# Altium schematic sheet lm1875 amplifier.SchDoc("lm1875 amplifier")
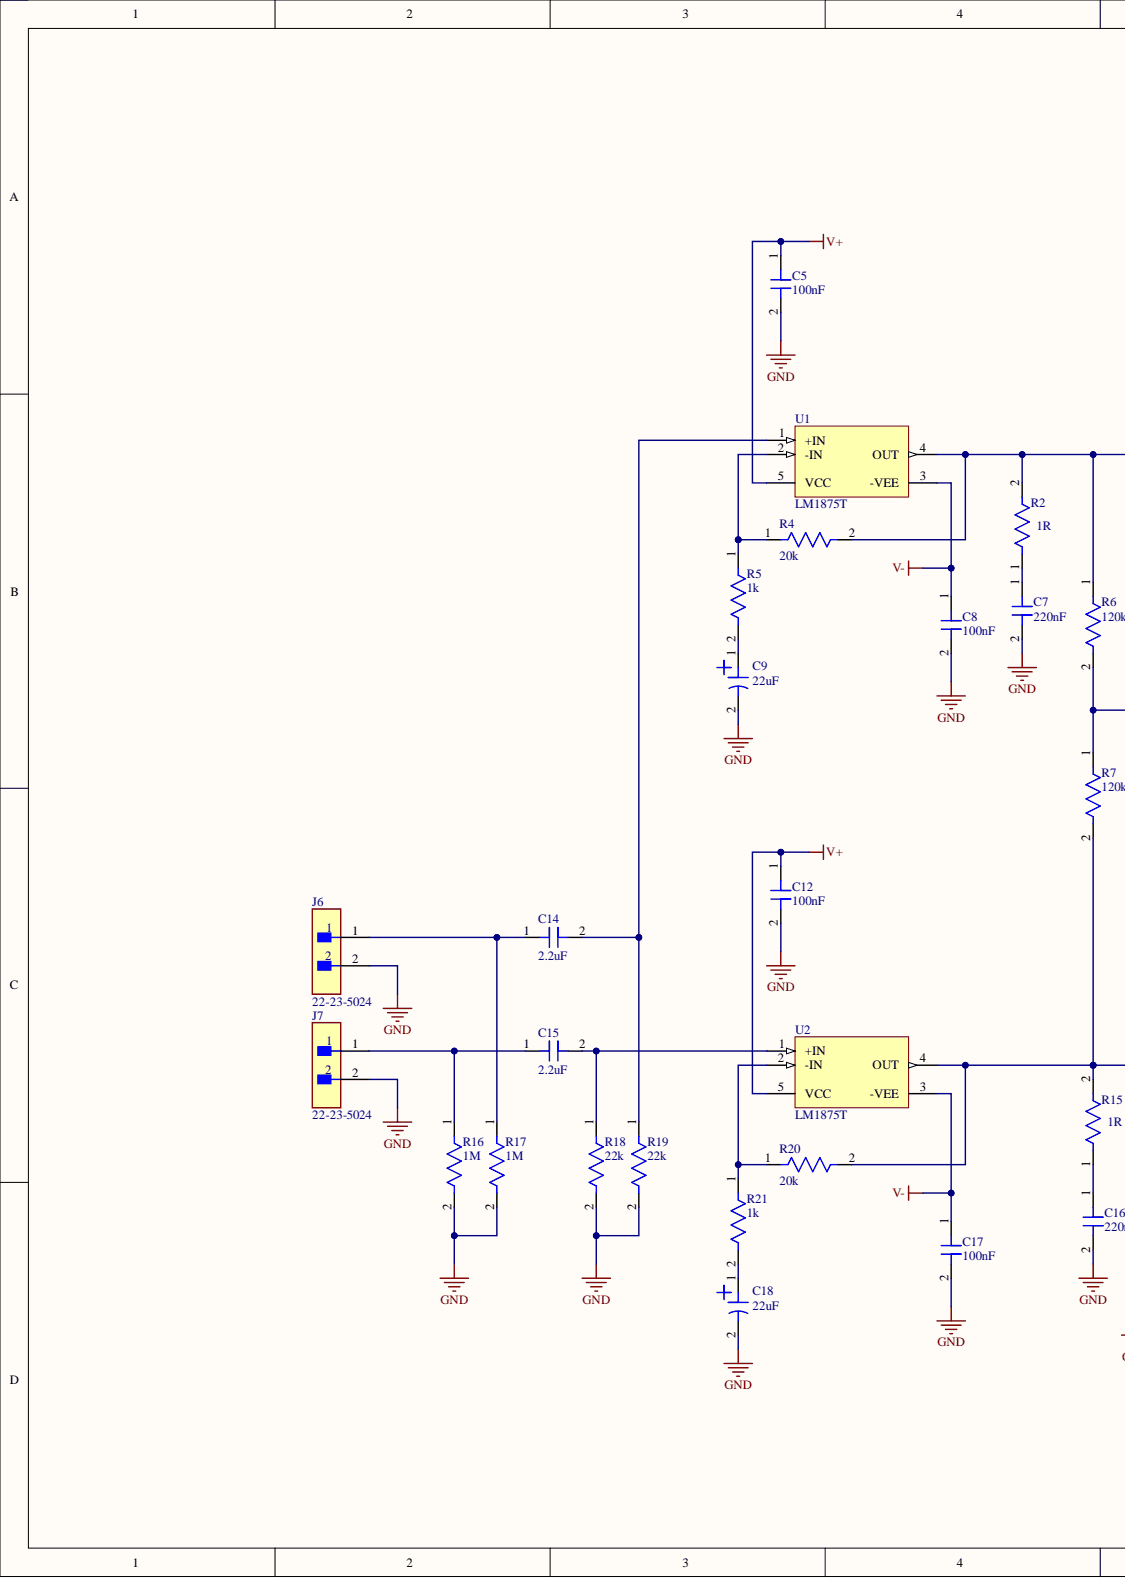
[diagram p0: lm1875 amplifier.SchDoc, left]
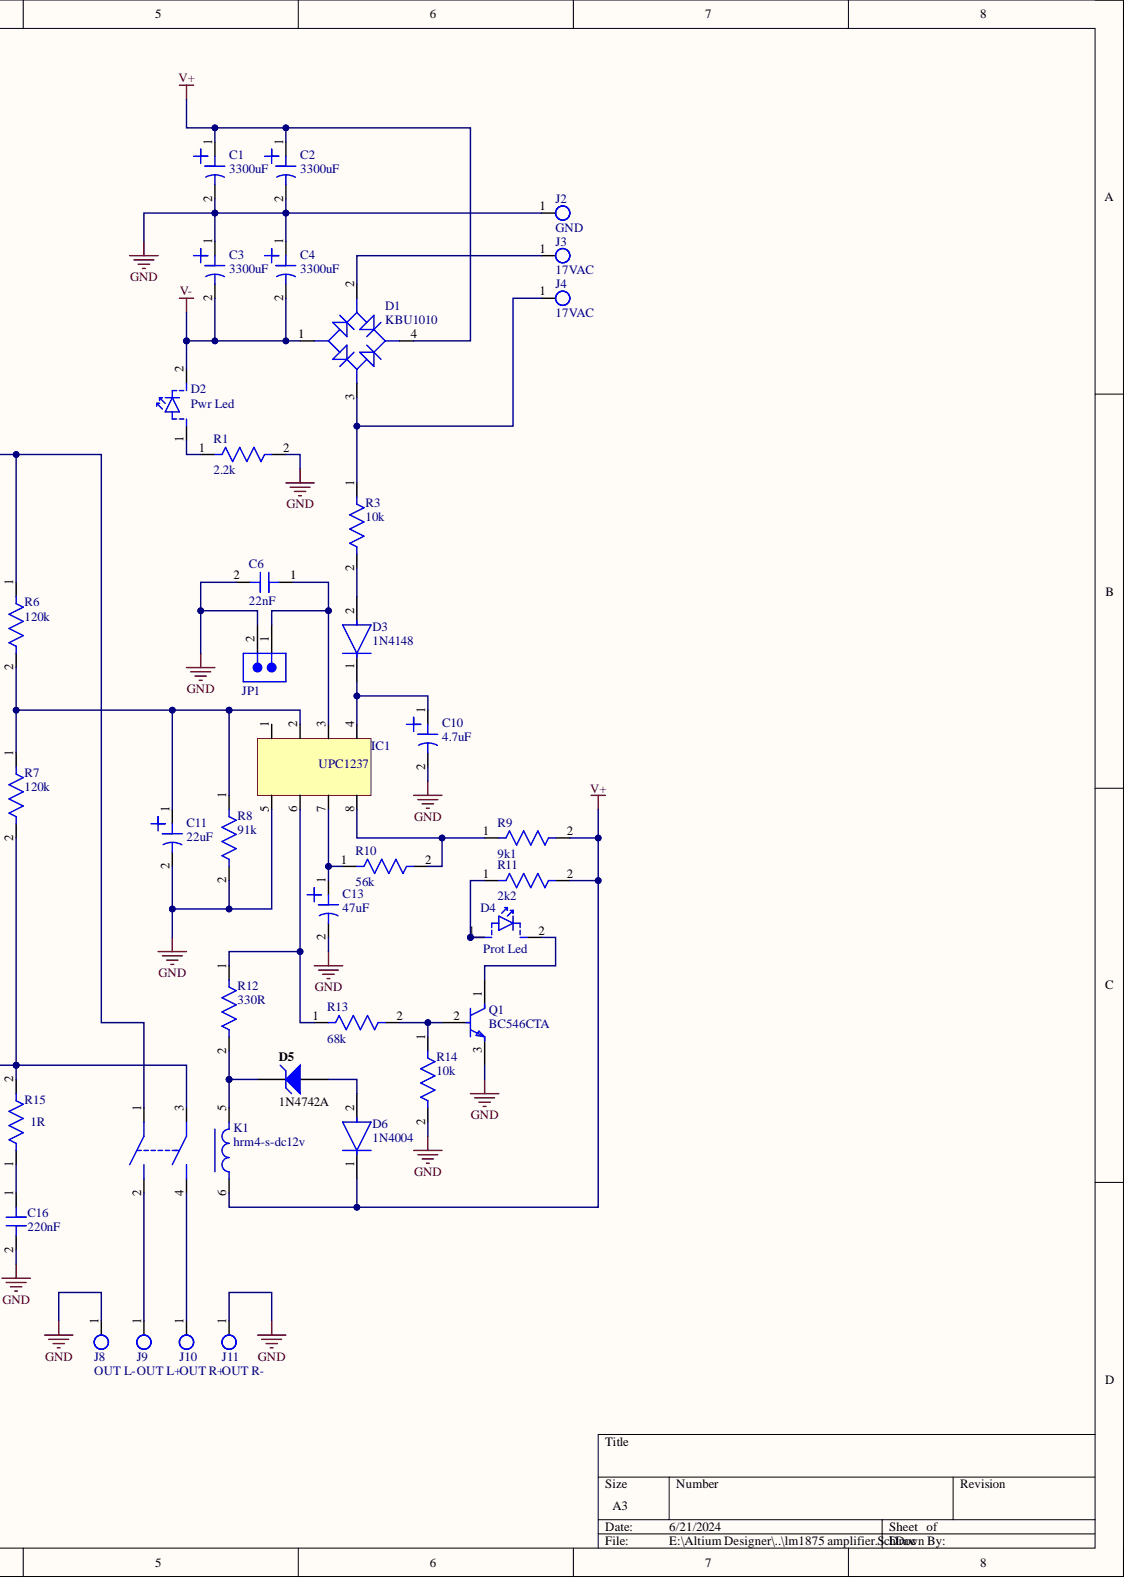
[diagram p1: lm1875 amplifier.SchDoc, right]

## Parts
C1  3300uF  [Capacitor electrolytic 16x21mm]
C2  3300uF  [Capacitor electrolytic 16x21mm]
C3  3300uF  [Capacitor electrolytic 16x21mm]
C4  3300uF  [Capacitor electrolytic 16x21mm]
C5  100nF  [Capacitor 3x7mm]
C6  22nF  [Capacitor 2.5x5mm]
C7  220nF  [Capacitor MKT 5x13mm]
C8  100nF  [Capacitor 3x7mm]
C9  22uF  [Capacitor 5x11mm]
C10  4.7uF  [Capacitor 5x11mm]
C11  22uF  [Capacitor 5x11mm]
C12  100nF  [Capacitor 3x7mm]
C13  47uF  [Capacitor 6x11mm]
C14  2.2uF  [Capacitor Bipolar 10x16mm Axial]
C15  2.2uF  [Capacitor Bipolar 10x16mm Axial]
C16  220nF  [Capacitor MKT 5x13mm]
C17  100nF  [Capacitor 3x7mm]
C18  22uF  [Capacitor 5x11mm]
D1  KBU1010  [KBU Rectifier]
D2  Pwr Led  [led 3mm]
D3  1N4148  [FP-017AG-MFG]
D4  Prot Led  [led 3mm]
D5  1N4742A  [FAIR-DO-41-2_V]
D6  1N4004  [FP-DO-41-MFG]
IC1  UPC1237  [SIP 8 PIN]
J2  GND  [Terminal 6.3mm male]
J3  17VAC  [Terminal 6.3mm male]
J4  17VAC  [Terminal 6.3mm male]
J6  22-23-5024  [FP-22-23-5024-MFG]
J7  22-23-5024  [FP-22-23-5024-MFG]
J8  OUT L-  [Terminal 6.3mm male]
J9  OUT L+  [Terminal 6.3mm male]
J10  OUT R+  [Terminal 6.3mm male]
J11  OUT R-  [Terminal 6.3mm male]
JP1  (no value)  [61300211121]
K1  hrm4-s-dc12v  [Relay hrm4-s-dc12v]
Q1  BC546CTA  [FP-135AR-MFG]
R1  2.2k  [Resistor 0207 1/4W]
R2  1R  [Resistor 1W]
R3  10k  [Resistor 0207 1/4W]
R4  20k  [Resistor 0207 1/4W wide legs]
R5  1k  [Resistor 0207 1/4W]
R6  120k  [Resistor 0207 1/4W]
R7  120k  [Resistor 0207 1/4W]
R8  91k  [Resistor 0207 1/4W]
R9  9k1  [Resistor 0207 1/4W]
R10  56k  [Resistor 0207 1/4W]
R11  2k2  [Resistor 0207 1/4W]
R12  330R  [Resistor 0207 1/4W]
R13  68k  [Resistor 0207 1/4W]
R14  10k  [Resistor 0207 1/4W]
R15  1R  [Resistor 1W]
R16  1M  [Resistor 0207 1/4W]
R17  1M  [Resistor 0207 1/4W]
R18  22k  [Resistor 0207 1/4W]
R19  22k  [Resistor 0207 1/4W]
R20  20k  [Resistor 0207 1/4W wide legs]
R21  1k  [Resistor 0207 1/4W]
U1  LM1875T  [NDH0005A]
U2  LM1875T  [NDH0005A]

## Nets
GND: C1.2 C2.2 C3.1 C4.1 C5.2 C6.2 C7.2 C8.2 C9.2 C10.2 C11.2 C12.2 C13.2 C16.2 C17.2 C18.2 IC1.5 J2.1 J6.2 J7.2 J8.1 J11.1 JP1.2 Q1.3 R1.2 R8.2 R14.2 R16.2 R17.2 R18.2 R19.2
V+: C1.1 C2.1 C5.1 C12.1 D1.4 D6.1 K1.6 R9.2 R11.2 U1.5(VCC) U2.5(VCC)
V-: C3.2 C4.2 C8.1 C17.1 D1.1 D2.2 U1.3(-VEE) U2.3(-VEE)
NetC6_1: C6.1 IC1.3 JP1.1
NetC7_1: C7.1 R2.1
NetC9_1: C9.1 R5.2
NetC10_1: C10.1 D3.1 IC1.4
NetC11_1: C11.1 IC1.2 R6.2 R7.1 R8.1
NetC13_1: C13.1 IC1.7 R10.1
NetC14_1: C14.1 J6.1 R17.1
NetC14_2: C14.2 R19.1 U1.1(+IN)
NetC15_1: C15.1 J7.1 R16.1
NetC15_2: C15.2 R18.1 U2.1(+IN)
NetC16_1: C16.1 R15.1
NetC18_1: C18.1 R21.2
NetD1_2: D1.2 J3.1
NetD1_3: D1.3 J4.1 R3.1
NetD2_1: D2.1 R1.1
NetD3_2: D3.2 R3.2
NetD4_1: D4.1 R11.1
NetD4_2: D4.2 Q1.1
NetD5_1: D5.1 D6.2
NetD5_2: D5.2 K1.5 R12.2
NetIC1_6: IC1.6 R12.1 R13.1
NetIC1_8: IC1.8 R9.1 R10.2
NetJ9_1: J9.1 K1.2
NetJ10_1: J10.1 K1.4
NetK1_1: K1.1 R2.2 R4.2 R6.1 U1.4(OUT)
NetK1_3: K1.3 R7.2 R15.2 R20.2 U2.4(OUT)
NetQ1_2: Q1.2 R13.2 R14.1
NetR4_1: R4.1 R5.1 U1.2(-IN)
NetR20_1: R20.1 R21.1 U2.2(-IN)

Not connected: IC1 1

## Library data of the parts
C1-C4 = Capacitor 16x21mm: Component Kind: Standard; Library Name: lm1875.SchLib
C5 C8 C12 C17 = Capacitor 3x7mm: Component Kind: Standard; Library Name: Schlib1.SchLib
C6 = Capacitor 2.5x5mm: Component Kind: Standard; Library Name: Schlib1.SchLib
C7 C16 = Capacitor MKT 5x13mm: Component Kind: Standard; Library Name: Schlib1.SchLib
C9-C11 C18 = Capacitor 5x11mm: Component Kind: Standard; Library Name: Schlib1.SchLib
C13 = Capacitor 6x11mm: Component Kind: Standard; Library Name: Schlib1.SchLib
C14 C15 = Capacitor Bipolar 10x16mm Axial: Component Kind: Standard; Library Name: Schlib1.SchLib
D1 = Diode Rectifier KBU: Component Kind: Standard; Library Name: Schlib1.SchLib
D2 D4 = Led 3mm: Component Kind: Standard; Library Name: Schlib1.SchLib
D3 = CMP-07163-000046-1: DIODE GEN PURP 100V 200MA DO35; Capacitance: 4pF; Current: 4A; Element Configuration: Single; Forward Current: 300mA; Forward Voltage: 1V; Max Forward Surge Current (Ifsm): 1A; Max Power Dissipation: 500mW; Max Reverse Current: 5uA; Outside Diameter: 1.85mm; Polarity: Standard; Recovery Time: 4ns; Average Rectified Current: 200mA; Case/Package: DO-35; Component Kind: Standard; Contact Plating: Tin; Current Rating: 200mA; Diameter: 1.91mm; Height: 4.56mm; Lead Free: Lead Free; Length: 4.56mm; Library Name: Altium Content Vault; Max Operating Temperature: 175°C; Max Repetitive Reverse Voltage (Vrrm): 100V; Max Reverse Voltage (DC): 100V; Max Surge Current: 4A; Min Operating Temperature: -65°C; Mount: Through Hole; Number of Pins: 2; Output Current: 200mA; Package Quantity: 2000; Packaging: Bulk; Peak Non-Repetitive Surge Current: 4A; Power Dissipation: 500mW; Radiation Hardening: No; REACH SVHC: No SVHC; Reverse Recovery Time: 4ns; Reverse Voltage: 100V; Series: -; Voltage: 100V; Voltage Rating (DC): 100V; Weight: 0.01lb; Width: 1.91mm
D5 = CMP-0892-00001-1: Zener Diode, 12V, 1W, 5%, -65 to 200 degC, 2-Pin DO-41, Body 2.72 x 5.2 mm, RoHS; Manufacturer: Fairchild Semiconductor; IR (uA): 5; PD (W): 1; Test Current IZ (mA): 21; VZ (Max.) (V): 12.6; VZ (Min.) (V): 11.4; ZZ (Max) (ohm): 8; Component Kind: Standard; ComponentLink1Description: Manufacturer URL; ComponentLink1URL: http://www.fairchildsemi.com/; ComponentLink2Description: Datasheet; ComponentLink2URL: http://www.fairchildsemi.com/ds/1N/1N4744A.pdf; ComponentLink3Description: Package Specification; ComponentLink3URL: http://www.fairchildsemi.com/dwg/DO/DO41A.pdf; DatasheetVersion: Rev. H3; Library Name: Altium Content Vault; Mounting Technology: Through Hole; Operating and Storage Temperature Range: -65 to 200 degC; PackageDescription: 2-Pin Axial leaded, Body 2.72 x 5.2 mm, Lead Spread 25.4 mm; PackageReference: DO-41-2; PackageVersion: Rev. 2; PartNumber: 1N4742A; RoHS: TRUE; Tolerance: 5%
D6 = CMP-56207-000012-1: DIODE GEN PURP 400V 1A DO41; Capacitance: 15pF; Element Configuration: Single; Forward Voltage: 1V; Max Forward Surge Current (Ifsm): 30A; Max Reverse Current: 5uA; Polarity: Standard; Recovery Time: 2000ns; Reverse Recovery Time: 2us; Average Rectified Current: 1A; Case/Package: DO-41; Component Kind: Standard; Current Rating: 1A; Diameter: 2.7mm; Forward Current: 1A; Lead Free: Lead Free; Length: 5.2mm; Library Name: Altium Content Vault; Max Operating Temperature: 150°C; Max Repetitive Reverse Voltage (Vrrm): 400V; Max Reverse Voltage (DC): 400V; Max Surge Current: 30A; Min Operating Temperature: -55°C; Mount: Through Hole; Number of Pins: 2; Output Current: 1A; Package Quantity: 1; Packaging: Tape and Reel; Peak Non-Repetitive Surge Current: 30A; Radiation Hardening: No; Reverse Voltage: 400V; RoHS Compliant: Yes; Voltage Rating (DC): 400V
J2-J4 J8-J11 = 6.3mm Male Terminal: Component Kind: Standard; Library Name: Schlib1.SchLib
J6 J7 = CMP-04776-000057-1: CONN HEADER VERT 2POS 2.54MM; Manufacturer: Molex; Conn Gender: Male; Conn Orientation: Straight; Current Rating: 4A; Number Of Contacts: 2; Voltage Rating: 500V; Automotive: No; Category: Conn; Component Kind: Standard; ComponentLink2Description: Datasheet; ComponentLink2URL: https://www.molex.com/pdm_docs/sd/022235024_sd.pdf; Contact Material: Tin,Nickel; Device Class L1: Connectors; Device Class L2: Headers and Wire Housings; Device Class L3: unset; Digikey Description: CONN HEADER VERT 2POS 2.54MM; Height: 8.43mm; Lead Free: Yes; Library Name: Altium Content Vault; Manufacturer Part Number: 22-23-5024; Mouser Description: Headers & Wire Housings KK 100 Hdr Assy FLk Assy FLk 02 ckt Tin; Number Of Rows: 1; Octopart: https://octopart.com/22-23-5024-molex-6792956; Package: 22-23-5024; Pitch: 2.54mm; RoHS: TRUE
JP1 = CMP-1712-00002-5: NexarPartId: 20081794; Connector Type: Male Pin; Contact Resistance: 20mΩ; Current Rating: 3A; Gender: Male; Number of Contacts: 2; Operating Supply Voltage: 250V; Pitch: 2.54mm; Color: Black; Component Kind: Standard; Contact Gender: Male; Contact Material: Copper; Contact Mating Length: 5.9944mm; Contact Plating: Gold; Height: 8.94mm; Housing Material: PBT; Lead Free: Lead Free; Lead Pitch: 2.54mm; Library Name: Altium Content Vault; Max Operating Temperature: 125°C; Min Operating Temperature: -40°C; Mount: Through Hole; Number of Pins: 2; Number of Positions: 2; Number of Rows: 1; Orientation: Vertical; Packaging: Bulk; REACH SVHC: No SVHC; RoHS: Compliant; Termination: Through Hole; Voltage Rating: 250V
K1 = Relay hrm4-s-dc12v: Component Kind: Standard; Library Name: Schlib1.SchLib
Q1 = CMP-00278-84408942-1: BC546CTA Small Signal Bipolar Transistor, 0.1A I(C), 65V V(BR)CEO, 1-Element, NPN, Silicon, TO-92; Manufacturer Lifecycle Status: ACTIVE; Collector Base Voltage (VCBO): 80V; Collector Emitter Saturation Voltage: 250mV; Current Rating: 100mA; Element Configuration: Single; Emitter Base Voltage (VEBO): 6V; Frequency: 300MHz; hFE Min: 110; Max Junction Temperature (Tj): 150°C; Max Power Dissipation: 500mW; Number of Elements: 1; Case/Package: TO-92-3; Collector Emitter Breakdown Voltage: 65V; Collector Emitter Voltage (VCEO): 65V; Component Kind: Standard; Contact Plating: Tin; Gain Bandwidth Product: 300MHz; Height: 4.58mm; Lead Free: Lead Free; Length: 4.58mm; Library Name: Altium Content Vault; Lifecycle Status: Production; Max Breakdown Voltage: 65V; Max Collector Current: 100mA; Max Frequency: 300MHz; Max Operating Temperature: 150°C; Min Operating Temperature: -65°C; Mount: Through Hole; NexarPartId: 84408942; Number of Pins: 3; Polarity: NPN; Power Dissipation: 500mW; Radiation Hardening: No; RoHS: Compliant; Schedule B: 8541210080, 8541210080; Transition Frequency: 300MHz; Voltage Rating (DC): 65V; Weight: 240mg; Width: 3.86mm
R1 R3-R14 R16-R21 = Resistor: Component Kind: Standard; Library Name: lm1875.SchLib
R2 R15 = Resistor 1W: Component Kind: Standard; Library Name: Schlib1.SchLib
U1 U2 = CMP-0057-00634-4: 20-W Audio Power Amplifier, 5-pin TO-220; Manufacturer: Texas Instruments; CompType: Audio IC; PackageTopMarking: NSUZXYTTE#*LM1875T; PowerWise: N; Component Kind: Standard; ComponentLink1Description: Datasheet URL; ComponentLink1URL: http://www.ti.com/general/docs/lit/getliterature.tsp?genericPartNumber=LM1875&fileType=pdf; Library Name: Altium Content Vault; PackageDescription: TO-220, Molded, Stagger Bend, 5 Lead; PackageReference: NDH0005A; PinCount: 5; RoHS: N
IC1 = UPC1237: Component Kind: Standard; Library Name: Schlib1.SchLib
